# Altium schematic sheet MainBoard_HMI.SchDoc
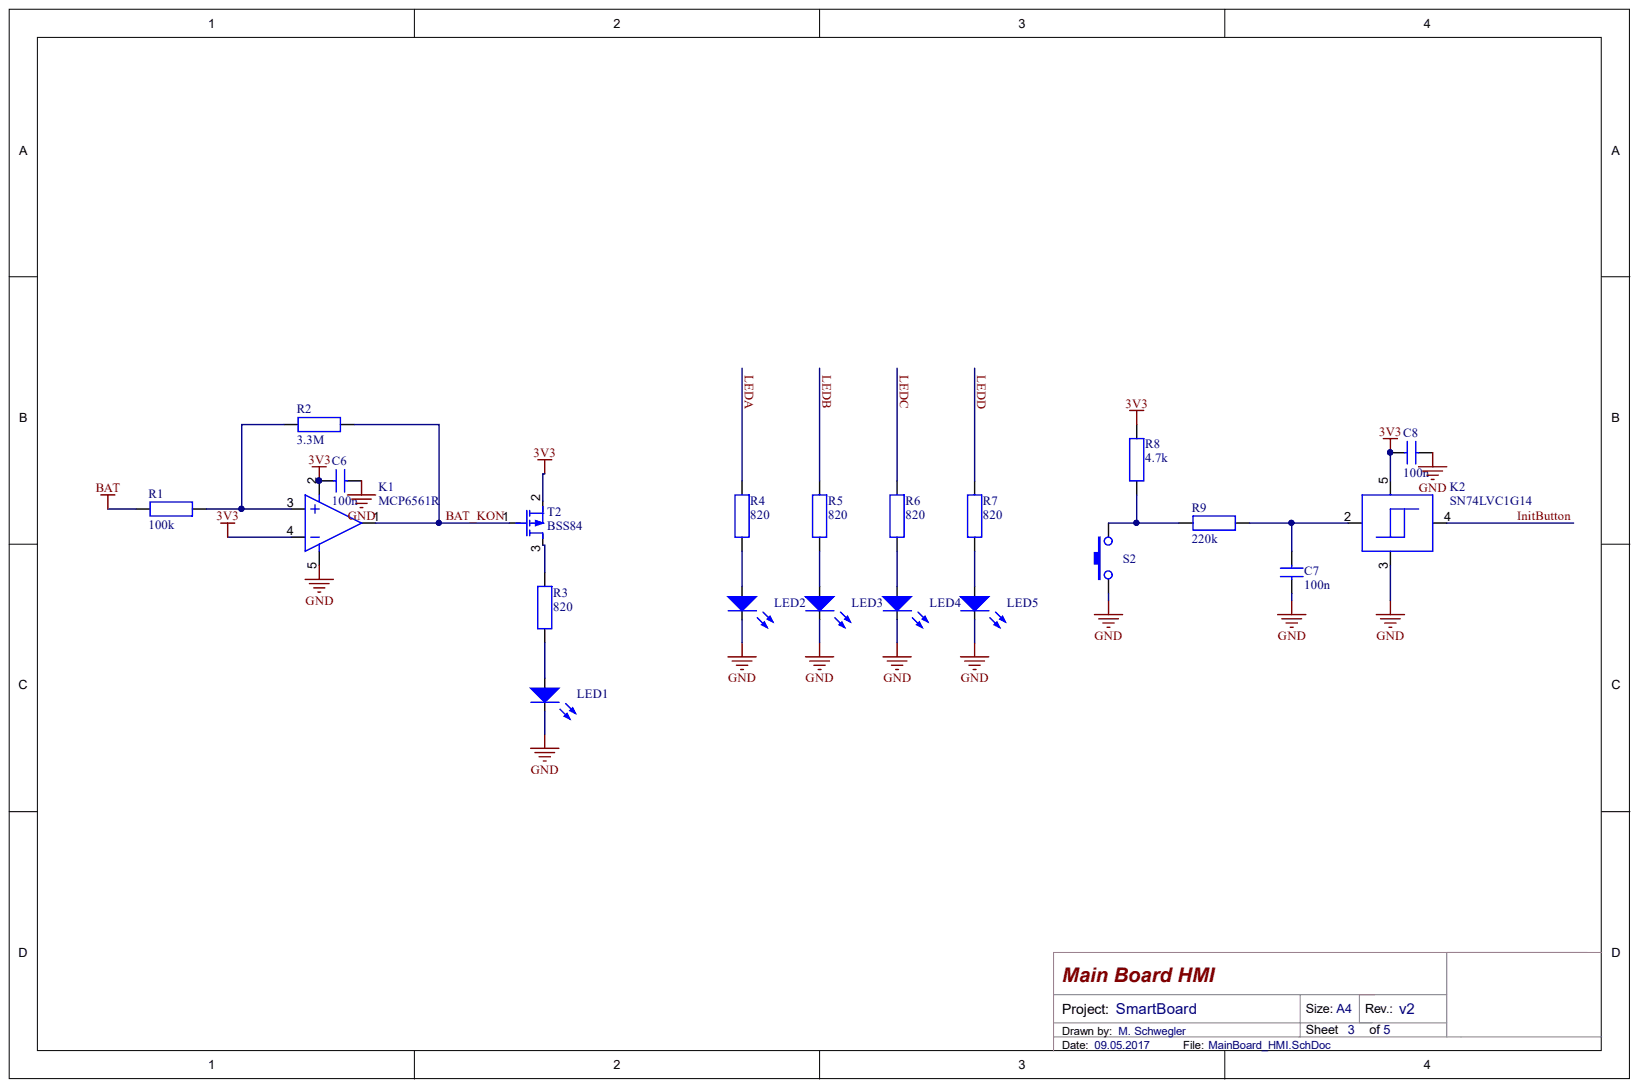
[diagram p0: MainBoard_HMI.SchDoc]

## Parts
C6  100n  [0805_C]
C7  100n  [0805_C]
C8  100n  [0805_C]
K1  MCP6561R  [SOT23-5]
K2  SN74LVC1G14  [SOT23-5]
LED1  Value  [LED_THT_3mm_RM_2.54mm]  (value not drawn)
LED2  Value  [LED_THT_3mm_RM_2.54mm]  (value not drawn)
LED3  Value  [LED_THT_3mm_RM_2.54mm]  (value not drawn)
LED4  Value  [LED_THT_3mm_RM_2.54mm]  (value not drawn)
LED5  Value  [LED_THT_3mm_RM_2.54mm]  (value not drawn)
R1  100k  [0805_R]
R2  3.3M  [0805_R]
R3  820  [0805_R]
R4  820  [0805_R]
R5  820  [0805_R]
R6  820  [0805_R]
R7  820  [0805_R]
R8  4.7k  [0805_R]
R9  220k  [0805_r]
S2  S2  [SIP2]
T2  BSS84  [SOT23-3]

## Nets
3V3: C6.1 C8.1 K1.2 K1.4 K2.5 R8.1 T2.2
BAT: R1.2
GND: C6.2 C7.1 C8.2 K1.5 K2.3 LED1.2 LED2.2 LED3.2 LED4.2 LED5.2 S2.1
BAT_KON: K1.1 R2.1 T2.1
INITBUTTON: K2.4
LEDA: R4.1
LEDB: R5.1
LEDC: R6.1
LEDD: R7.1
NetC7_2: C7.2 K2.2 R9.2
NetK1_3: K1.3 R1.1 R2.2
NetLED1_1: LED1.1 R3.2
NetLED2_1: LED2.1 R4.2
NetLED3_1: LED3.1 R5.2
NetLED4_1: LED4.1 R6.2
NetLED5_1: LED5.1 R7.2
NetR3_1: R3.1 T2.3
NetR8_2: R8.2 R9.1 S2.2

## Library data of the parts
C6-C8 = C?: Normaler Kondensator; Component Kind: Standard; Library Name: MainBoard.SCHLIB
K1 = OPAMP: Component Kind: Standard; Library Name: MainBoard.SCHLIB
K2 = schmitt: Component Kind: Standard; Library Name: MainBoard.SCHLIB
LED1-LED5 = LED: Component Kind: Standard; Library Name: Sch_Lib.SchLib
R1-R9 = R?: Normaler Widerstand; Component Kind: Standard; Library Name: MainBoard.SCHLIB
S2 = SW-PB: Component Kind: Standard; Library Name: DEVICE_HSLU.SchLib
T2 = MOSFET-P 1D,2G,3S: Component Kind: Standard; Description: MOSFET-P 1D,2G,3S; Library Name: Sch_Lib.SchLib
